# Altium schematic sheet PowerSupply.SchDoc(PowerSupply)
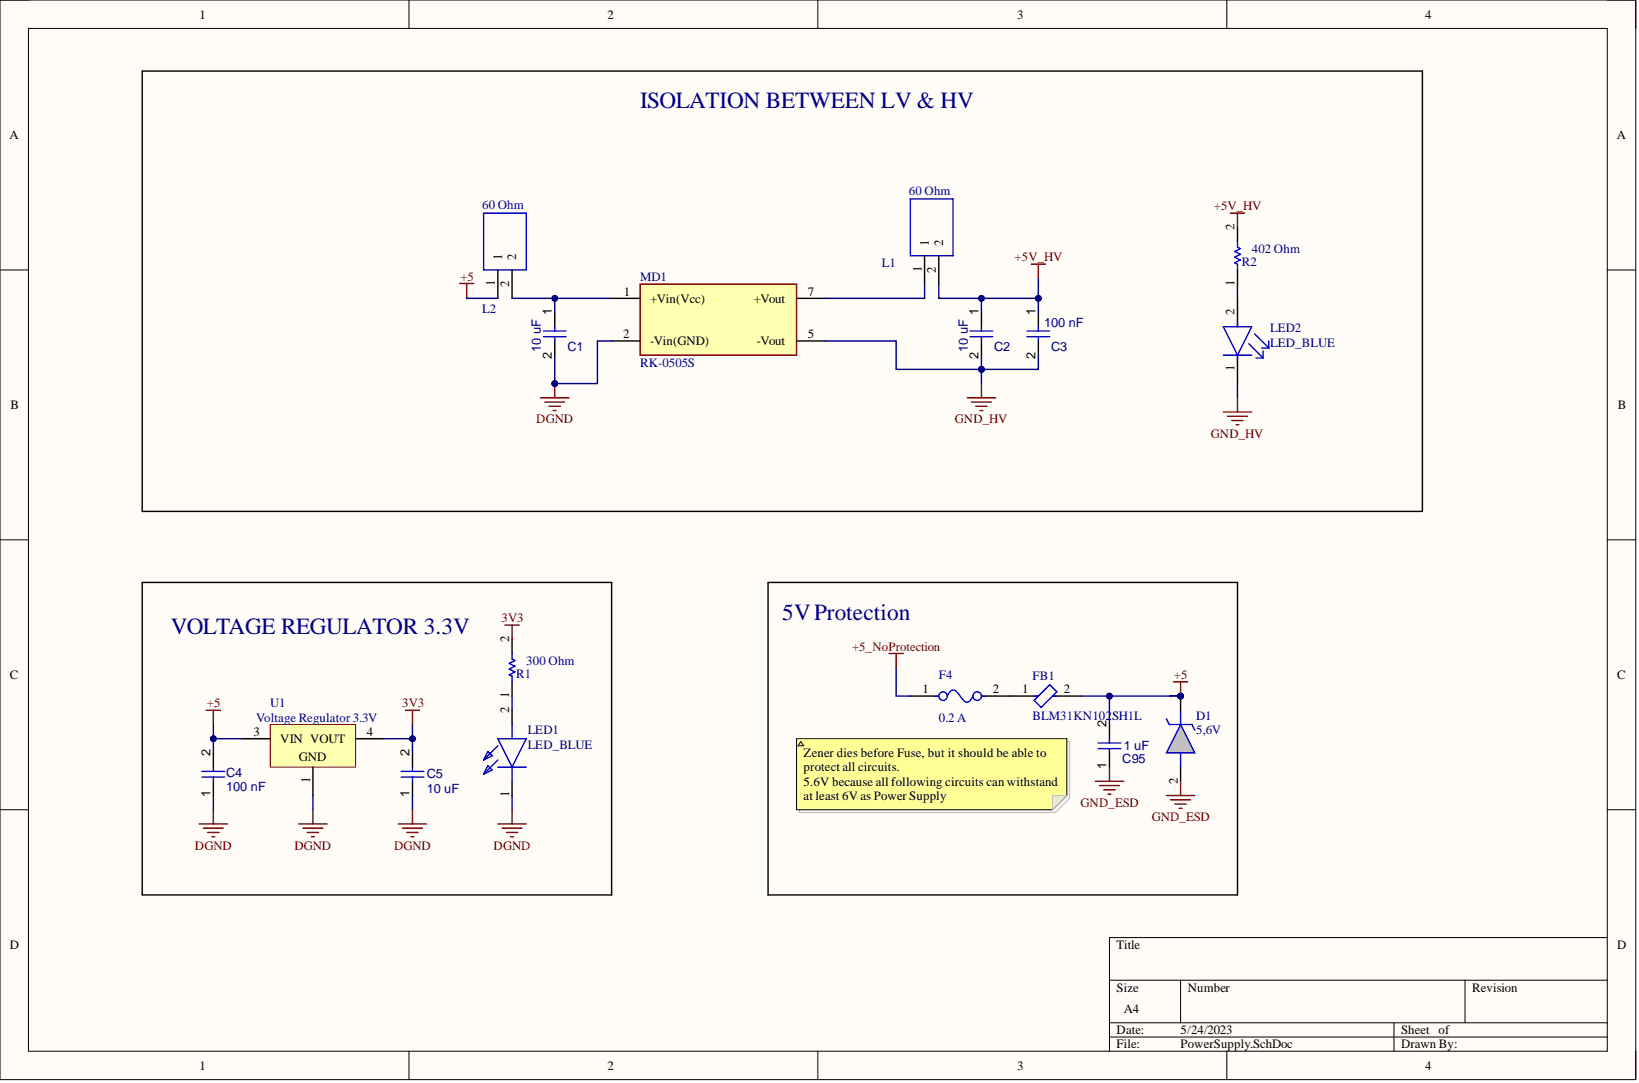
[diagram p0: PowerSupply.SchDoc]

## Parts
C1  10 uF
C2  10 uF
C3  100 nF
C4  100 nF
C5  10 uF
C95  1 uF
D1  5,6V
F4  0.2 A
FB1  BLM31KN102SH1L
L1  60 Ohm
L2  60 Ohm
LED1  LED_BLUE
LED2  LED_BLUE
MD1  RK-0505S
R1  300 Ohm
R2  402 Ohm
U1  Voltage Regulator 3.3V

## Nets
3V3: C5.2 R1.2 U1.4
+5: C4.2 C95.2 D1.1 FB1.2 L2.1 U1.3
+5_NoProtection: F4.1
+5V_HV: C2.1 C3.1 L1.2 R2.2
DGND: C1.2 C4.1 C5.1 LED1.1 MD1.2(-Vin(GND)) U1.1
GND_ESD: C95.1 D1.2
GND_HV: C2.2 C3.2 LED2.1 MD1.5(-Vout)
NetC1_1: C1.1 L2.2 MD1.1(+Vin(Vcc))
NetF4_2: F4.2 FB1.1
NetL1_1: L1.1 MD1.7(+Vout)
NetLED1_2: LED1.2 R1.1
NetLED2_2: LED2.2 R2.1

## Library data of the parts
C1 C2 C5 = CMP-001-00085-2: GRM21BR71A106KA73K; Tech: SMD; Component Kind: Standard; ComponentLink1Description: Website; Package: 0805; Library Name: EMEA- Squadra Corse PoliTO-  Politecnico di Torino
  C5: ComponentLink1URL: https://www.mouser.it/ProductDetail/Vishay-Vitramon/VJ0805G106MXQTW1BC?qs=PqoDHHvF64%2FiIFVCZpIM5w%3D%3D
C3 C4 = CMP-001-00085-2: VJ0805Y104KBABE31; Tech: SMD; Component Kind: Standard; ComponentLink1Description: Website; Package: 0805; Library Name: EMEA- Squadra Corse PoliTO-  Politecnico di Torino
C95 = CMP-001-00085-2: VJ0805Y105KXXTW1BC; Tech: SMD; Component Kind: Standard; ComponentLink1Description: Website; ComponentLink1URL: https://www.mouser.it/ProductDetail/Vishay-Vitramon/VJ0805V105MXQPW1BC?qs=wtJBjE46HQsbbuoG%2F6UKXw%3D%3D; Package: 0805; Library Name: EMEA- Squadra Corse PoliTO-  Politecnico di Torino
D1 = CMP-095-000000-1: DDZ5V6ASF-7; Manufacturer_Name: Diodes Inc.; Geometry.Height: 0.75mm; Component Kind: Standard; Datasheet Link: https://componentsearchengine.com/Datasheets/1/DDZ5V6ASF-7.pdf; Design Item ID: DDZ5V6ASF-7; Manufacturer_Part_Number: DDZ5V6ASF-7; Mouser Part Number: 621-DDZ5V6ASF-7; Mouser Price/Stock: https://www.mouser.com/Search/Refine.aspx?Keyword=621-DDZ5V6ASF-7; Source: DDZ5V6ASF-7.SchLib; Library Name: EMEA- Squadra Corse PoliTO-  Politecnico di Torino
F4 = CMP-08605-000096-1: 0466.200NRHF; Approvals: UL; Current: 200mA; DC Cold Resistance: 1.16R; Fuse Type: Board Mount (Cartridge Style Excluded); Power Dissipation: 50.9mW; Voltage Rating (AC): 125V; Case Code (Imperial): 1206; Case Code (Metric): 3216; Case/Package: SMD/SMT; Component Kind: Standard; Current Rating: 200mA; Depth: 1.52mm; Height: 0.023inch; Housing Material: Ceramic; Lead Free: Contains Lead; Length: 3.18mm; Library Name: Altium Content Vault; Max Operating Temperature: 90°C; Min Operating Temperature: -55°C; Mount: Surface Mount; Number of Pins: 2; Package Quantity: 5000; Packaging: Tape and Reel; Radiation Hardening: No; RoHS Compliant: Yes; Series: SlimLine™ 466; Voltage Rating (DC): 125V; Width: 0.06inch
FB1 = CMP-06046-002291-1: DC Resistance (DCR): 75mR; Max Current Rating: 2A; Number of Lines: 1; Case Code (Imperial): 1206; Case Code (Metric): 3216; Case/Package: 1206; Component Kind: Standard; Description: BLM31KN102SH1L; Library Name: Altium Content Vault; Max Operating Temperature: 125°C; Min Operating Temperature: -55°C; Mount: Surface Mount; Packaging: Cut Tape; Ratings: AEC-Q200; RoHS Compliant: Yes
L1 L2 = CMP-056-000000-1: ILHB0805ER600V; Tech: SMD; Component Kind: Standard; Package: 0805; Library Name: EMEA- Squadra Corse PoliTO-  Politecnico di Torino
LED1 = CMP-031-000016-1: APT2012LVBC_D; Manufacturer_Name: Kingbright; Manufacturer_Part_Number: APT2012LVBC/D; Tech: SMD; Arrow Part Number: APT2012LVBC/D; Arrow Price/Stock: https://www.arrow.com/en/products/apt2012lvbcd/kingbright; Component Kind: Standard; ComponentLink1Description: Website; ComponentLink1URL: https://www.mouser.it/ProductDetail/Kingbright/APT2012LVBC-D?qs=6oMev5NRZMGErJYT6KZLXg%3D%3D; Datasheet Link: https://componentsearchengine.com/Datasheets/1/APT2012LVBC_D.pdf; Design Item ID: APT2012LVBC_D; Height: 0.85mm; Mouser Part Number: 604-APT2012LVBCD; Mouser Price/Stock: https://www.mouser.co.uk/ProductDetail/Kingbright/APT2012LVBC-D?qs=6oMev5NRZMGErJYT6KZLXg%3D%3D; Package: 0805; Source: SamacSys.SchLib; Library Name: EMEA- Squadra Corse PoliTO-  Politecnico di Torino
LED2 = CMP-007-00036-1: VLMB1300-GS08; Tech: SMD; Component Kind: Standard; ComponentLink1Description: Website; ComponentLink1URL: https://www.mouser.it/ProductDetail/Vishay-Semiconductors/VLMB1300-GS08?qs=uoVnq4yU%252B9K3zw%252BhVoDOXQ%3D%3D; Package: 0603; Library Name: EMEA- Squadra Corse PoliTO-  Politecnico di Torino
MD1 = CMP-49942-000020-1: DC DC CONVERTER 5V 1W; Efficiency: 78%; Isolation Voltage: 4kV; Max Input Voltage: 5.5V; Max Output Current: 200mA; Min Input Voltage: 4.5V; Number of Outputs: 1; Component Kind: Standard; Library Name: Altium Content Vault; Max Operating Temperature: 95°C; Max Power Dissipation: 1W; Min Operating Temperature: -40°C; Mount: Through Hole; Series: TEA 1HI (1W); Voltage - Output 1: 5V
R1 = CMP-009-00179-4: CRCW0603300RFKEA; Tech: SMD; Component Kind: Standard; ComponentLink1Description: Website; ComponentLink1URL: https://www.mouser.it/ProductDetail/Vishay-Dale/CRCW0603300RFKEA?qs=SNwsiWikH8qfoKtotWEteQ%3D%3D; Package: 0603; Library Name: EMEA- Squadra Corse PoliTO-  Politecnico di Torino
R2 = CMP-009-00179-4: CRCW0603402RFKEA; Tech: SMD; Component Kind: Standard; ComponentLink1Description: Website; ComponentLink1URL: https://www.mouser.it/ProductDetail/Vishay-Dale/CRCW0603402RFKEA?qs=PlgT7GGookHxID%2FOfdNhwg%3D%3D; Package: 0603; Library Name: EMEA- Squadra Corse PoliTO-  Politecnico di Torino
U1 = CMP-0244-00089-1: LD1117DT33CTR; Tech: SMD; Component Kind: Standard; ComponentLink1Description: Manufacturer URL; ComponentLink1URL: http://www.st.com; ComponentLink2Description: Datasheet; ComponentLink2URL: http://www.st.com/internet/com/TECHNICAL_RESOURCES/TECHNICAL_LITERATURE/DATASHEET/CD00000544.pdf; ComponentLink3Description: Website; ComponentLink3URL: https://www.mouser.it/ProductDetail/STMicroelectronics/LD1117DT33CTR?qs=rUxiu3TV3%2FNm%2FOyb7vJzpg%3D%3D; DatasheetVersion: 1/11/2011; Library Name: Altium Content Vault; PackageDescription: 3-Pin Transistor Outline Package 2.28 mm Pitch, 9.7 mm Lead Span (DPAK); PackageReference: TO-252; PackageVersion: 2011
